# Altium schematic sheet bee_hive_1.SchDoc
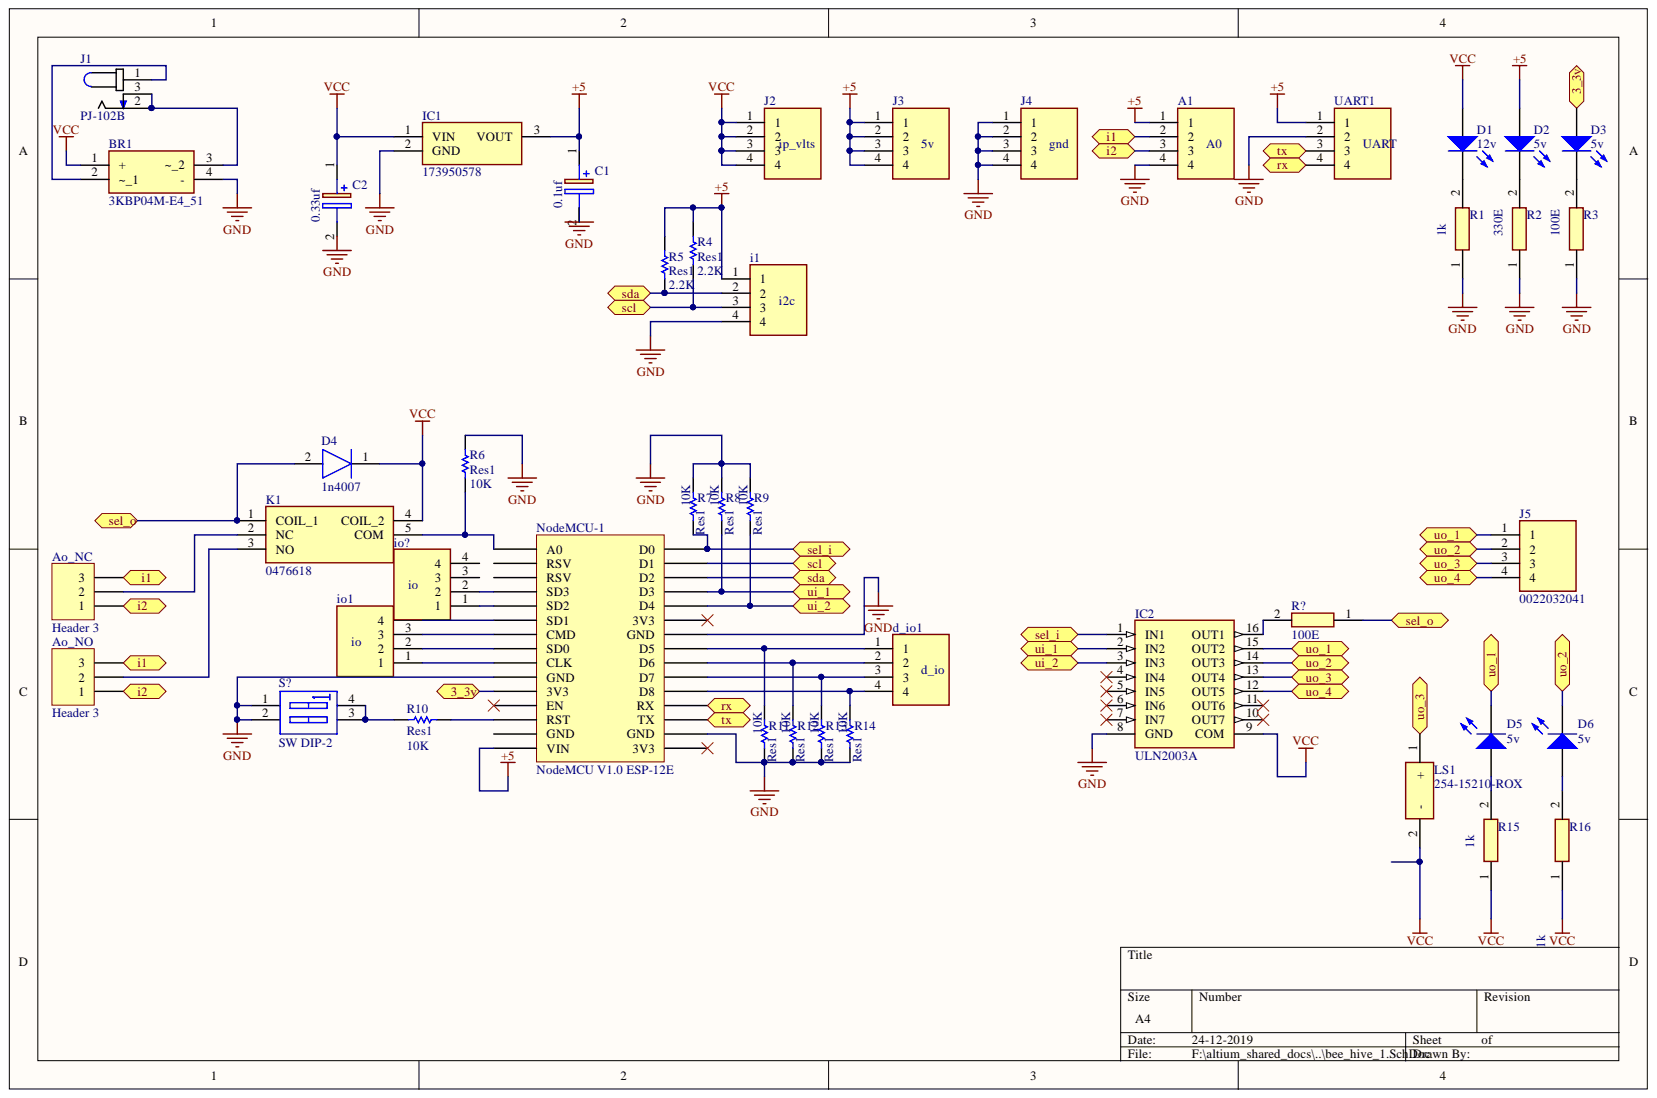
[diagram p0: bee_hive_1.SchDoc]

## Parts
A1  A0  [HDRV4W87P0X254_1X4_991X236X1155P]
Ao_NC  Header 3  [HDR1X3]
Ao_NO  Header 3  [HDR1X3]
BR1  3KBP04M-E4_51  [TO385P508X1473X1168-4P]
C1  0.1uf  [CAPPRD250W50D680H1250]
C2  0.33uf  [CAPPRD250W50D680H1250]
D1  12v  [LED-0]
D2  5v  [LED-0]
D3  5v  [LED-0]
D4  1n4007  [DIOAD2902W106L900D500]
D5  5v  [LED-0]
D6  5v  [LED-0]
d_io1  d_io  [HDRV4W87P0X254_1X4_991X236X1155P]
i1  i2c  [HDRV4W87P0X254_1X4_991X236X1155P]
IC1  173950578  [173950578]
IC2  ULN2003A  [DIP794W56P254L1918H533Q16N]
io1  io  [HDRV4W87P0X254_1X4_991X236X1155P]
io?  io  [HDRV4W87P0X254_1X4_991X236X1155P]
J1  PJ-102B  [*CUI_PJ-102B]
J2  ip_vlts  [HDRV4W87P0X254_1X4_991X236X1155P]
J3  5v  [HDRV4W87P0X254_1X4_991X236X1155P]
J4  gnd  [HDRV4W87P0X254_1X4_991X236X1155P]
J5  0022032041  [HDRV4W87P0X254_1X4_991X236X1155P]
K1  0476618  [0476618]
LS1  254-15210-ROX  [25415210ROX]
NodeMCU-1  NodeMCU V1.0 ESP-12E  [NodeMCU V1.0 ESP-12E]
R1  1k  [RESAD1650W60L650D250]
R2  330E  [RESAD1650W60L650D250]
R3  100E  [RESAD1650W60L650D250]
R4  Res1  value 2.2K  [AXIAL-0.3]
R5  Res1  value 2.2K  [AXIAL-0.3]
R6  Res1  value 10K  [AXIAL-0.3]
R7  Res1  value 10K  [AXIAL-0.3]
R8  Res1  value 10K  [AXIAL-0.3]
R9  Res1  value 10K  [AXIAL-0.3]
R10  Res1  value 10K  [AXIAL-0.3]
R11  Res1  value 10K  [AXIAL-0.3]
R12  Res1  value 10K  [AXIAL-0.3]
R13  Res1  value 10K  [AXIAL-0.3]
R14  Res1  value 10K  [AXIAL-0.3]
R15  1k  [RESAD1650W60L650D250]
R16  1k  [RESAD1650W60L650D250]
R?  100E  [RESAD1650W60L650D250]
S?  SW DIP-2  [DIP-4]
UART1  UART  [HDRV4W87P0X254_1X4_991X236X1155P]

## Ports
3_3v, i1, i2, rx, scl, sda, sel_i, sel_o, tx, ui_1, ui_2, uo_1, uo_2, uo_3, uo_4

## Nets
+5: A1.1 C1.1 D2.1 i1.1 IC1.3 J3.1 J3.2 J3.3 J3.4 NodeMCU-1.VIN R4.2 R5.2 UART1.1
GND: A1.4 BR1.4(-) C1.2 C2.2 i1.4 IC1.2 IC2.8(GND) J4.1 J4.2 J4.3 J4.4 NodeMCU-1.GND R1.1 R2.1 R3.1 R6.2 R7.1 R8.1 R9.1 R11.2 R12.2 R13.2 R14.2 S?.1 S?.2 UART1.2
VCC: BR1.1(+) C2.1 D1.1 D4.1 IC1.1 IC2.9(COM) J2.1 J2.2 J2.3 J2.4 K1.4(COIL_2) LS1.2 R15.1 R16.1
3_3v: D3.1 NodeMCU-1.3V3 ; port 3_3v
i1: A1.2 Ao_NC.3 Ao_NO.3 ; port i1
i2: A1.3 Ao_NC.1 Ao_NO.1 ; port i2
rx: NodeMCU-1.RX UART1.4 ; port rx
scl: i1.3 NodeMCU-1.D1 R4.1 ; port scl
sda: i1.2 NodeMCU-1.D2 R5.1 ; port sda
sel_i: IC2.1(IN1) NodeMCU-1.D0 R7.2 ; port sel_i
sel_o: D4.2 K1.1(COIL_1) R?.1 ; port sel_o
tx: NodeMCU-1.TX UART1.3 ; port tx
ui_1: IC2.2(IN2) NodeMCU-1.D3 R8.2 ; port ui_1
ui_2: IC2.3(IN3) NodeMCU-1.D4 R9.2 ; port ui_2
uo_1: D5.2 IC2.15(OUT2) J5.1 ; port uo_1
uo_2: D6.2 IC2.14(OUT3) J5.2 ; port uo_2
uo_3: IC2.13(OUT4) J5.3 LS1.1 ; port uo_3
uo_4: IC2.12(OUT5) J5.4 ; port uo_4
NetAo_NC_2: Ao_NC.2 K1.2(NC)
NetAo_NO_2: Ao_NO.2 K1.3(NO)
NetBR1_2: BR1.2(~_1) J1.1
NetBR1_3: BR1.3(~_2) J1.2 J1.3
NetD1_2: D1.2 R1.2
NetD2_2: D2.2 R2.2
NetD3_2: D3.2 R3.2
NetD5_1: D5.1 R15.2
NetD6_1: D6.1 R16.2
Netd_io1_1: d_io1.1 NodeMCU-1.D5 R11.1
Netd_io1_2: d_io1.2 NodeMCU-1.D6 R12.1
Netd_io1_3: d_io1.3 NodeMCU-1.D7 R13.1
Netd_io1_4: d_io1.4 NodeMCU-1.D8 R14.1
NetIC2_16: IC2.16(OUT1) R?.2
Netio1_1: io1.1 NodeMCU-1.CLK
Netio1_2: io1.2 NodeMCU-1.SD0
Netio1_3: io1.3 NodeMCU-1.CMD
Netio1_4: io1.4 NodeMCU-1.SD1
Netio?_1: io?.1 NodeMCU-1.SD2
Netio?_2: io?.2 NodeMCU-1.SD3
NetK1_5: K1.5(COM) NodeMCU-1.A0 R6.1
NetNodeMCU-1_RST: NodeMCU-1.RST R10.1
NetR10_2: R10.2 S?.3 S?.4

Not connected: IC2 4(IN4) 5(IN5) 6(IN6) 7(IN7) 10(OUT7) 11(OUT6); io? 3 4; NodeMCU-1 EN RSV

## Library data of the parts
A1 d_io1 i1 io1 io? J2-J5 UART1 = 0022032041: Connector; Manufacturer_Name: Molex; Component Kind: Standard; Datasheet Link: http://www.molex.com/pdm_docs/sd/022032041_sd.pdf; Height: 11.55mm; Library Name: SamacSys.SchLib; Manufacturer_Part_Number: 0022032041; Mouser Part Number: N/A; Mouser Price/Stock: https://www.mouser.com/Search/Refine.aspx?Keyword=N%2FA
Ao_NC Ao_NO = Header 3: Header, 3-Pin; Component Kind: Standard; LatestRevisionDate: 17-Jul-2002; LatestRevisionNote: Re-released for DXP Platform.; Library Name: Miscellaneous Connectors.IntLib; Signal Integrity: Connector; Publisher: Altium Limited
BR1 = 3KBP04M-E4_51: Bridge Rectifier; Manufacturer_Name: Vishay; Manufacturer_Part_Number: 3KBP04M-E4/51; Component Kind: Standard; Datasheet Link: https://www.vishay.com/docs/88888/3kbp08m.pdf; Height: 5.08mm; Mouser Part Number: 625-3KBP04M-E4; Mouser Price/Stock: https://www.mouser.com/Search/Refine.aspx?Keyword=625-3KBP04M-E4; RS Part Number: 8116150; RS Price/Stock: https://uk.rs-online.com/web/p/products/8116150
C1 C2 = BKME500ELL100MF11D: Capacitor Polarised; Manufacturer_Name: United Chemi-Con; Component Kind: Standard; Datasheet Link: http://www.mouser.com/datasheet/2/420/al-kmebp-e-140701-514734.pdf; Height: 12.5mm; Manufacturer_Part_Number: BKME500ELL100MF11D; Mouser Part Number: 661-BKME500ELL100MF1; Mouser Price/Stock: https://www.mouser.com/Search/Refine.aspx?Keyword=661-BKME500ELL100MF1
D1-D3 D5 D6 = LED0: Typical INFRARED GaAs LED; Component Kind: Standard; LatestRevisionDate: 08-Jul-2005; LatestRevisionNote: Mech Layer 1 removed.; Library Name: Miscellaneous Devices.IntLib; PackageDescription: LED; 2 Leads; PackageReference: LED-0; Published: 8-Jun-2000; Simulation: LED0; Publisher: Altium Limited
D4 = 1.5KE68CA-T: Diode; Manufacturer_Name: Diodes Inc.; Component Kind: Standard; Datasheet Link: https://www.diodes.com/assets/Datasheets/ds21503.pdf; Manufacturer_Part_Number: 1.5KE68CA-T; Mouser Part Number: 621-1.5KE68CA-T; Mouser Price/Stock: https://www.mouser.com/Search/Refine.aspx?Keyword=621-1.5KE68CA-T
IC1 = 173950578: Integrated Circuit; Manufacturer_Name: Wurth Elektronik; Component Kind: Standard; Datasheet Link: https://katalog.we-online.com/pm/datasheet/173950578.pdf; Height: 10.5mm; Manufacturer_Part_Number: 173950578; Mouser Part Number: 710-173950578; Mouser Price/Stock: https://www.mouser.com/Search/Refine.aspx?Keyword=710-173950578; RS Part Number: 1447817P; RS Price/Stock: http://uk.rs-online.com/web/p/products/1447817P
IC2 = ULN2003A: Integrated Circuit; Manufacturer_Name: STMicroelectronics; Allied_Number: 70014189; Allied Price/Stock: http://www.alliedelec.com/stmicroelectronics-uln2003a/70014189/; Component Kind: Standard; ComponentLink1Description: datashet; ComponentLink1URL: https://www.seeedstudio.com/document/pdf/ULN2003%20Datasheet.pdf; Datasheet Link: http://www.st.com/web/en/resource/technical/document/datasheet/CD00001244.pdf; Height: 5.33mm; Manufacturer_Part_Number: ULN2003A; Mouser Part Number: 511-ULN2003A; Mouser Price/Stock: https://www.mouser.com/Search/Refine.aspx?Keyword=511-ULN2003A; RS Part Number: 6868209; RS Price/Stock: http://uk.rs-online.com/web/p/products/6868209
K1 = 0476618: Relay or Contactor; Manufacturer_Name: RS Components; Component Kind: Standard; ComponentLink1Description: datasheet; ComponentLink1URL: https://www.hobbytronics.co.uk/datasheets/songle-12v-relay.pdf; Datasheet Link: https://docs-emea.rs-online.com/webdocs/1583/0900766b81583163.pdf; Height: 15mm; Manufacturer_Part_Number: 0476618
LS1 = 254-15210-ROX: Loudspeaker or Buzzer; Manufacturer_Name: Kobitone; Component Kind: Standard; Datasheet Link: https://www.mouser.de/datasheet/2/209/KT-400269-1172018.pdf; Height: 8.51mm; Manufacturer_Part_Number: 254-15210-ROX
NodeMCU-1 = NodeMCU V1.0 ESP-12E: NodeMCU V1.0 ESP8266 (ESP-12E); Component Kind: Standard; Library Name: nodemcu.IntLib
R1-R3 R15 R16 R? = MRS25000C1000FCT00: Resistor; Manufacturer_Name: Vishay; Component Kind: Standard; Datasheet Link: http://www.vishay.com/docs/28724/mrs16m25.pdf; Manufacturer_Part_Number: MRS25000C1000FCT00; Mouser Part Number: 594-MRS25000C1000FCT; Mouser Price/Stock: https://www.mouser.com/Search/Refine.aspx?Keyword=594-MRS25000C1000FCT
R4-R14 = Res1: Resistor; Component Kind: Standard; LatestRevisionDate: 17-Jul-2002; LatestRevisionNote: Re-released for DXP Platform.; Library Name: Miscellaneous Devices.IntLib; PackageDescription: Axial Device, Thru-Hole; 2 Leads; 0.3 in Pin Spacing; PackageReference: AXIAL-0.3; Published: 8-Jun-2000; Signal Integrity: Res; Simulation: RESISTOR; Publisher: Altium Limited
S? = SW DIP-2: DIP Switch, 2 Position, SPST; Component Kind: Standard; LatestRevisionDate: 17-Jul-2002; LatestRevisionNote: Re-released for DXP Platform.; Library Name: Miscellaneous Devices.IntLib; PackageReference: DIP-4; Published: 24-Mar-1999; Simulation: dpsw2; Publisher: Altium Limited
J1 = PJ-102B: Component Kind: Standard; Library Name: PJ-102B.SchLib
